# Altium schematic sheet conn_board_adc.SchDoc
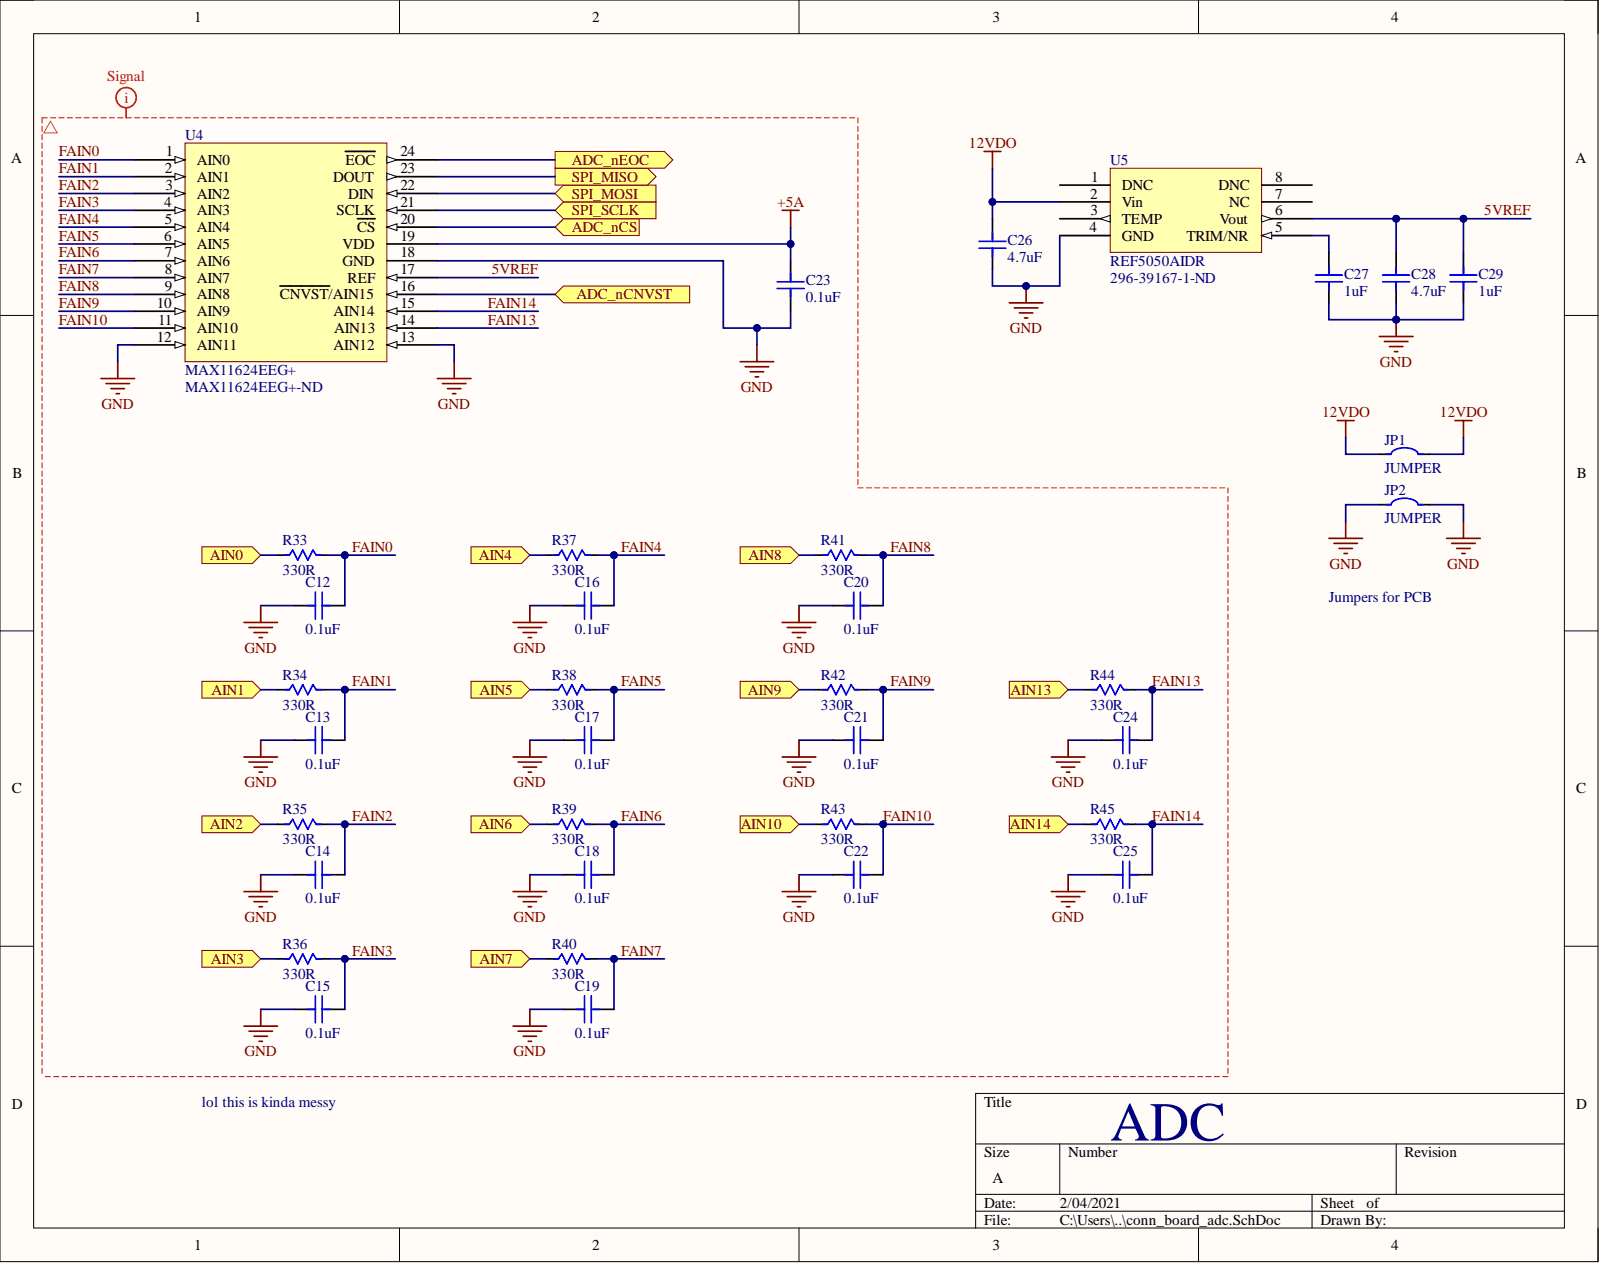
[diagram p0: conn_board_adc.SchDoc]

## Parts
C12  0.1uF  [CAP_0805]
C13  0.1uF  [CAP_0805]
C14  0.1uF  [CAP_0805]
C15  0.1uF  [CAP_0805]
C16  0.1uF  [CAP_0805]
C17  0.1uF  [CAP_0805]
C18  0.1uF  [CAP_0805]
C19  0.1uF  [CAP_0805]
C20  0.1uF  [CAP_0805]
C21  0.1uF  [CAP_0805]
C22  0.1uF  [CAP_0805]
C23  0.1uF  [CAP_0805]
C24  0.1uF  [CAP_0805]
C25  0.1uF  [CAP_0805]
C26  4.7uF  [CAP_0805]
C27  1uF  [CAP_0805]
C28  4.7uF  [CAP_0805]
C29  1uF  [CAP_0805]
JP1  JUMPER  [JMP_20MM]  (jumper)
JP2  JUMPER  [JMP_20MM]  (jumper)
R33  330R  [RES_0805_PANASONIC]
R34  330R  [RES_0805_PANASONIC]
R35  330R  [RES_0805_PANASONIC]
R36  330R  [RES_0805_PANASONIC]
R37  330R  [RES_0805_PANASONIC]
R38  330R  [RES_0805_PANASONIC]
R39  330R  [RES_0805_PANASONIC]
R40  330R  [RES_0805_PANASONIC]
R41  330R  [RES_0805_PANASONIC]
R42  330R  [RES_0805_PANASONIC]
R43  330R  [RES_0805_PANASONIC]
R44  330R  [RES_0805_PANASONIC]
R45  330R  [RES_0805_PANASONIC]
U4  MAX11624EEG+  Supplier Part Number 1: MAX11624EEG+-ND  [QSOP24]
U5  REF5050AIDR  Supplier Part Number 1: 296-39167-1-ND  [SOIC_8]

## Ports
ADC_nCNVST, ADC_nCS, ADC_nEOC, AIN0, AIN1, AIN2, AIN3, AIN4, AIN5, AIN6, AIN7, AIN8, AIN9, AIN10, AIN13, AIN14, SPI_MISO, SPI_MOSI, SPI_SCLK

## Nets
12VDO: C26.2 JP1.1 JP1.2 U5.2(Vin)
GND: C12.1 C13.1 C14.1 C15.1 C16.1 C17.1 C18.1 C19.1 C20.1 C21.1 C22.1 C23.2 C24.1 C25.1 C26.1 C27.1 C28.1 C29.1 JP2.1 JP2.2 U4.12(AIN11) U4.13(AIN12) U4.18(GND) U5.4(GND)
5VREF: C23.1 C28.2 C29.2 U4.17(REF) U4.19(VDD) U5.6(Vout)
ADC_nCNVST: U4.16(CNVST/AIN15) ; port ADC_nCNVST
ADC_nCS: U4.20(CS) ; port ADC_nCS
ADC_nEOC: U4.24(EOC) ; port ADC_nEOC
AIN0: R33.1 ; port AIN0
AIN1: R34.1 ; port AIN1
AIN2: R35.1 ; port AIN2
AIN3: R36.1 ; port AIN3
AIN4: R37.1 ; port AIN4
AIN5: R38.1 ; port AIN5
AIN6: R39.1 ; port AIN6
AIN7: R40.1 ; port AIN7
AIN8: R41.1 ; port AIN8
AIN9: R42.1 ; port AIN9
AIN10: R43.1 ; port AIN10
AIN13: R44.1 ; port AIN13
AIN14: R45.1 ; port AIN14
FAIN0: C12.2 R33.2 U4.1(AIN0)
FAIN1: C13.2 R34.2 U4.2(AIN1)
FAIN2: C14.2 R35.2 U4.3(AIN2)
FAIN3: C15.2 R36.2 U4.4(AIN3)
FAIN4: C16.2 R37.2 U4.5(AIN4)
FAIN5: C17.2 R38.2 U4.6(AIN5)
FAIN6: C18.2 R39.2 U4.7(AIN6)
FAIN7: C19.2 R40.2 U4.8(AIN7)
FAIN8: C20.2 R41.2 U4.9(AIN8)
FAIN9: C21.2 R42.2 U4.10(AIN9)
FAIN10: C22.2 R43.2 U4.11(AIN10)
FAIN13: C24.2 R44.2 U4.14(AIN13)
FAIN14: C25.2 R45.2 U4.15(AIN14)
SPI_MISO: U4.23(DOUT) ; port SPI_MISO
SPI_MOSI: U4.22(DIN) ; port SPI_MOSI
SPI_SCLK: U4.21(SCLK) ; port SPI_SCLK
NetC27_2: C27.2 U5.5(TRIM/NR)

Not connected: U5 1(DNC) 3(TEMP) 7(NC) 8(DNC)

## Library data of the parts
C12-C25 = C0805C104M3RACTU: CAP CER 0.1UF 25V X7R 0805; Manufacturer: KEMET; Capacitance: 100nF; Dielectric Material: Ceramic; Dissipation Factor: 5%; Tolerance: 20%; Case Code (Imperial): 0805; Case Code (Metric): 2012; Case/Package: 0805; Category: Capacitor; Component Kind: Standard; ComponentLink1Description: http://www.mouser.com/ds/2/212/KEM_C1002_X7R_SMD-1102033.pdf?src-supplier=Mouser; ComponentLink1URL: http://www.mouser.com/ds/2/212/KEM_C1002_X7R_SMD-1102033.pdf?src-supplier=Mouser; ComponentLink2Description: http://uk.rs-online.com/webdocs/13da/0900766b813da9ff.pdf?src-supplier=RSComponents; ComponentLink2URL: http://uk.rs-online.com/webdocs/13da/0900766b813da9ff.pdf?src-supplier=RSComponents; ComponentLink3Description: http://components.arrow.com/part/dataview/0323_00178100?src-supplier=Arrow+Europe; ComponentLink3URL: http://components.arrow.com/part/dataview/0323_00178100?src-supplier=Arrow+Europe; ComponentLink4Description: https://www.alliedelec.com/m/d/6f3b5eb83d09db50ed28bdc730567b33.pdf?src-supplier=Allied+Electronics; ComponentLink4URL: https://www.alliedelec.com/m/d/6f3b5eb83d09db50ed28bdc730567b33.pdf?src-supplier=Allied+Electronics; ComponentLink5Description: http://www.farnell.com/datasheets/2746330.pdf?src-supplier=Farnell; ComponentLink5URL: http://www.farnell.com/datasheets/2746330.pdf?src-supplier=Farnell; ComponentLink6Description: http://www.jameco.com/Jameco/Products/ProdDS/1566487.pdf?src-supplier=Jameco; ComponentLink6URL: http://www.jameco.com/Jameco/Products/ProdDS/1566487.pdf?src-supplier=Jameco; ComponentLink7Description: http://components.arrow.com/part/dataview/2091097S3491315N7429?src-supplier=Arrow+Electronics; ComponentLink7URL: http://components.arrow.com/part/dataview/2091097S3491315N7429?src-supplier=Arrow+Electronics; ComponentLink8Description: https://www.alliedelec.com/m/d/e7aa2b5497e2375e4ffc0e8be19e16e2.pdf?src-supplier=Allied+Electronics; ComponentLink8URL: https://www.alliedelec.com/m/d/e7aa2b5497e2375e4ffc0e8be19e16e2.pdf?src-supplier=Allied+Electronics; ComponentLink9Description: http://uk.rs-online.com/webdocs/13da/0900766b813daa05.pdf?src-supplier=RSComponents; ComponentLink9URL: http://uk.rs-online.com/webdocs/13da/0900766b813daa05.pdf?src-supplier=RSComponents; ComponentLink10Description: http://www.farnell.com/datasheets/2746330.pdf?src-supplier=Element14; ComponentLink10URL: http://www.farnell.com/datasheets/2746330.pdf?src-supplier=Element14; ComponentLink11Description: https://api.kemet.com/component-edge/download/datasheet/C0805C104M3RACTU.pdf?src-supplier=Digi-Key; ComponentLink11URL: https://api.kemet.com/component-edge/download/datasheet/C0805C104M3RACTU.pdf?src-supplier=Digi-Key; ComponentLink12Description: http://www.farnell.com/datasheets/1828800.pdf?src-supplier=Newark; ComponentLink12URL: http://www.farnell.com/datasheets/1828800.pdf?src-supplier=Newark; ComponentLink13Description: http://www.farnell.com/datasheets/2746330.pdf?src-supplier=Newark; ComponentLink13URL: http://www.farnell.com/datasheets/2746330.pdf?src-supplier=Newark; ComponentLink14Description: http://capacitoredge.kemet.com/capedge2/DataSheet?pn=C0805C104M3RACTU&src-supplier=Avnet; ComponentLink14URL: http://capacitoredge.kemet.com/capedge2/DataSheet?pn=C0805C104M3RACTU&src-supplier=Avnet; Depth: 1.25mm; Dielectric: X7R; Height: 0.9mm; Lead Pitch: 0.75mm; Length: 2mm; Library Name: Schlib1.SchLib; Manufacturer Part Number: C0805C104M3RACTU; Max Operating Temperature: 125°C; Min Operating Temperature: -55°C; Mount: Surface Mount; Package Quantity: 1; Packaging: Tape and Reel; Pricing 1: 1=0.10000, 10=0.06800, 50=0.03700, 100=0.03020, 500=0.02160, 1000=0.01697 (USD); Radiation Hardening: No; REACH SVHC: No SVHC; RoHS: Yes; Stock 1: 305175; Supplier 1: Digi-Key; Supplier Part Number 1: 399-8000-1-ND; Termination: SMD/SMT; Thickness: 0.035inch; Voltage: 25V; Voltage Rating: 25V; Voltage Rating (DC): 25V; Width: 0.049inch
C26 C28 = CL21A475KAQNNNE: SAMSUNG ELECTRO-MECHANICS - CL21A475KAQNNNE - SMD Multilayer Ceramic Capacitor, 4.7 µF, 25 V, 0805 [2012 Metric], ± 10%, X5R, CL Series; Voltage Rating: 25V; Capacitance: 4.7uF; Case Code (Imperial): 0805; Case Code (Metric): 2012; Case/Package: 0805; Category: Ceramic Capacitors; Component Kind: Standard; ComponentLink1Description: https://datasheet.ciiva.com/25798/cl21a475kaqnnne-25798882.pdf?src-supplier=Digi-Key; ComponentLink1URL: https://datasheet.ciiva.com/25798/cl21a475kaqnnne-25798882.pdf?src-supplier=Digi-Key; Depth: 1.25mm; Dielectric: X5R; Height: 1.25mm; Lead Free: Lead Free; Length: 2mm; Library Name: Schlib1.SchLib; Manufacturer: Samsung; Manufacturer Part Number: CL21A475KAQNNNE; Max Operating Temperature: 85°C; Min Operating Temperature: -55°C; Mount: Surface Mount; Package Quantity: 1; Packaging: Tape and Reel; Pricing 1: 1=0.11000, 10=0.08100, 50=0.04600, 100=0.03820, 500=0.02678, 1000=0.02216 (USD); Radiation Hardening: No; RoHS: Yes; Stock 1: 671990; Supplier 1: Digi-Key; Supplier Part Number 1: 1276-1244-1-ND; Thickness: 0.055inch; Tolerance: 10%; Voltage Rating (DC): 25V; Width: 0.049inch
C27 C29 = C0805C105Z4VACTU: Cap Ceramic 1uF 16V Y5V -20% to 80% Pad SMD 0805 85C T/R; Manufacturer: KEMET; Dissipation Factor: 7%; Capacitance: 1uF; Case Code (Imperial): 0805; Case Code (Metric): 2012; Case/Package: 0805; Category: Capacitor; Component Kind: Standard; ComponentLink1Description: https://datasheet.ciiva.com/6074/1698780-6074369.pdf?src-supplier=Farnell; ComponentLink1URL: https://datasheet.ciiva.com/6074/1698780-6074369.pdf?src-supplier=Farnell; ComponentLink2Description: https://datasheet.ciiva.com/16623/datasheetpn-c0805c105z4vac7800-16623623.pdf?src-supplier=Avnet+Europe; ComponentLink2URL: https://datasheet.ciiva.com/16623/datasheetpn-c0805c105z4vac7800-16623623.pdf?src-supplier=Avnet+Europe; ComponentLink3Description: https://datasheet.ciiva.com/14604/70095383-14604106.pdf?src-supplier=Allied+Electronics; ComponentLink3URL: https://datasheet.ciiva.com/14604/70095383-14604106.pdf?src-supplier=Allied+Electronics; ComponentLink4Description: https://datasheet.ciiva.com/7600/1698780-7600029.pdf?src-supplier=Newark; ComponentLink4URL: https://datasheet.ciiva.com/7600/1698780-7600029.pdf?src-supplier=Newark; ComponentLink5Description: https://datasheet.ciiva.com/14589/70095383-14589407.pdf?src-supplier=Allied+Electronics; ComponentLink5URL: https://datasheet.ciiva.com/14589/70095383-14589407.pdf?src-supplier=Allied+Electronics; ComponentLink6Description: http://datasheet.octopart.com/C0805C105Z4VACTU-Kemet-datasheet-13692877.pdf?src-supplier=Element14; ComponentLink6URL: http://datasheet.octopart.com/C0805C105Z4VACTU-Kemet-datasheet-13692877.pdf?src-supplier=Element14; ComponentLink7Description: http://datasheet.octopart.com/C0805C105Z4VACTU-Kemet-datasheet-503297.pdf?src-supplier=Newark; ComponentLink7URL: http://datasheet.octopart.com/C0805C105Z4VACTU-Kemet-datasheet-503297.pdf?src-supplier=Newark; ComponentLink8Description: http://datasheet.octopart.com/C0805C105Z4VACTU-KEMET-datasheet-101576167.pdf?src-supplier=Farnell; ComponentLink8URL: http://datasheet.octopart.com/C0805C105Z4VACTU-KEMET-datasheet-101576167.pdf?src-supplier=Farnell; ComponentLink9Description: http://datasheet.octopart.com/C0805C105Z4VACTU-KEMET-datasheet-83957834.pdf?src-supplier=TME; ComponentLink9URL: http://datasheet.octopart.com/C0805C105Z4VACTU-KEMET-datasheet-83957834.pdf?src-supplier=TME; ComponentLink10Description: http://datasheet.octopart.com/C0805C105Z4VACTU-Kemet-datasheet-5313890.pdf?src-supplier=Verical; ComponentLink10URL: http://datasheet.octopart.com/C0805C105Z4VACTU-Kemet-datasheet-5313890.pdf?src-supplier=Verical; ComponentLink11Description: http://datasheet.octopart.com/C0805C105Z4VAC-TU-Kemet-datasheet-8204015.pdf?src-supplier=Jameco; ComponentLink11URL: http://datasheet.octopart.com/C0805C105Z4VAC-TU-Kemet-datasheet-8204015.pdf?src-supplier=Jameco; ComponentLink12Description: http://datasheet.octopart.com/C0805C105Z4VACTU-Kemet-datasheet-464.pdf?src-supplier=Allied+Electronics; ComponentLink12URL: http://datasheet.octopart.com/C0805C105Z4VACTU-Kemet-datasheet-464.pdf?src-supplier=Allied+Electronics; ComponentLink13Description: http://datasheet.octopart.com/C0805C105Z4VACTU-Kemet-datasheet-11554470.pdf?src-supplier=Arrow; ComponentLink13URL: http://datasheet.octopart.com/C0805C105Z4VACTU-Kemet-datasheet-11554470.pdf?src-supplier=Arrow; ComponentLink14Description: http://datasheet.octopart.com/C0805C105Z4VACTU-Kemet-datasheet-66128697.pdf?src-supplier=Element14; ComponentLink14URL: http://datasheet.octopart.com/C0805C105Z4VACTU-Kemet-datasheet-66128697.pdf?src-supplier=Element14; ComponentLink15Description: http://datasheet.octopart.com/C0805C105Z4VACTU-Kemet-datasheet-66325528.pdf?src-supplier=Newark; ComponentLink15URL: http://datasheet.octopart.com/C0805C105Z4VACTU-Kemet-datasheet-66325528.pdf?src-supplier=Newark; ComponentLink16Description: http://datasheet.octopart.com/C0805C105Z4VACTU-Kemet-datasheet-67847115.pdf?src-supplier=TME; ComponentLink16URL: http://datasheet.octopart.com/C0805C105Z4VACTU-Kemet-datasheet-67847115.pdf?src-supplier=TME; ComponentLink17Description: http://datasheet.octopart.com/C0805C105Z4VACTU-KEMET-datasheet-84169754.pdf; ComponentLink17URL: http://datasheet.octopart.com/C0805C105Z4VACTU-KEMET-datasheet-84169754.pdf; ComponentLink18Description: http://datasheet.octopart.com/C0805C105Z4VACTU-KEMET-datasheet-41193421.pdf?src-supplier=Master+Electronics; ComponentLink18URL: http://datasheet.octopart.com/C0805C105Z4VACTU-KEMET-datasheet-41193421.pdf?src-supplier=Master+Electronics; Contact Material: Tin; Depth: 1.25mm; Dielectric: Y5V; Dielectric Material: Ceramic; Height: 1.25mm; Lead Free: Lead Free; Lead Pitch: 0.75mm; Length: 2mm; Library Name: Schlib1.SchLib; Manufacturer Part Number: C0805C105Z4VACTU; Max Operating Temperature: 85°C; Min Operating Temperature: -30°C; Mount: Surface Mount; Number of Pins: 2; Package Quantity: 1; Packaging: Tape and Reel; Pricing 1: 1=0.11000, 10=0.08100, 50=0.04420, 100=0.03620, 500=0.02584, 1000=0.02030 (USD); Radiation Hardening: No; REACH SVHC: No SVHC; Stock 1: 83211; Supplier 1: Digi-Key; Supplier Part Number 1: 399-8011-1-ND; Termination: SMD/SMT; Thickness: 0.055inch; Tolerance: 80%; Voltage: 16V; Voltage Rating: 16V; Voltage Rating (DC): 16V; Width: 0.049inch
R33-R45 = ERA-6AED331V: Res Thin Film 0805 330 Ohm 0.5% 0.125W(1/8W) ±25ppm/C Molded SMD Automotive Punched T/R; Manufacturer: Panasonic; Power Rating: 125mW; Temperature Coefficient: 25ppm/°C; Voltage Rating: 200V; Case Code (Imperial): 0805; Case Code (Metric): 2012; Case/Package: 0805; Category: Resistor; Component Kind: Standard; ComponentLink1Description: https://datasheet.ciiva.com/24071/aoa0000ce26-197354-24071480.pdf?src-supplier=Mouser; ComponentLink1URL: https://datasheet.ciiva.com/24071/aoa0000ce26-197354-24071480.pdf?src-supplier=Mouser; ComponentLink2Description: https://datasheet.ciiva.com/9182/aoa0000ce26-9182970.pdf?src-supplier=Avnet; ComponentLink2URL: https://datasheet.ciiva.com/9182/aoa0000ce26-9182970.pdf?src-supplier=Avnet; Contact Plating: Tin; Depth: 1.25mm; Height: 0.5mm; Lead Free: Lead Free; Length: 2mm; Library Name: Schlib1.SchLib; Manufacturer Part Number: ERA-6AED331V; Max Operating Temperature: 155°C; Military Standard: Not; Min Operating Temperature: -55°C; Mount: Surface Mount; Package Quantity: 5000; Packaging: Tape and Reel; Pricing 1: 1=0.10000, 10=0.09400, 25=0.06480, 50=0.05040, 100=0.03600, 250=0.02808, 500=0.02232, 1000=0.01584 (USD); Resistance: 330R; Resistor Type: Thin Film; RoHS: Yes; Stock 1: 11862; Supplier 1: Digi-Key; Supplier Part Number 1: P123837CT-ND; Termination: SMD/SMT; Tolerance: 0.5%; Width: 1.25mm
U4 = MAX11624EEG+: GLOVE, POLYAMIDE, L/FREE, PU, SIZE 6 - More Details; Manufacturer: Maxim; Conversion Rate: 300KS/s; Interface: SPI,Serial; Max Power Dissipation: 762mW; Number of A/D Converters: 1; Number of Analog Inputs: 16; Number of Bits: 10; Operating Supply Voltage: 5.25V; Polarity: Unipolar; Resolution: 10b; Sampling Rate: 300000S/s; Signal to Noise Ratio (SNR): 49dB; Supply Type: Single; Case/Package: QSOP; Category: IC; Component Kind: Standard; ComponentLink1Description: https://datasheet.ciiva.com/16611/max11618-max11625-16611389.pdf?src-supplier=Avnet+Europe; ComponentLink1URL: https://datasheet.ciiva.com/16611/max11618-max11625-16611389.pdf?src-supplier=Avnet+Europe; ComponentLink2Description: https://datasheet.ciiva.com/3039/max11618-max11625-3039027.pdf?src-supplier=Avnet; ComponentLink2URL: https://datasheet.ciiva.com/3039/max11618-max11625-3039027.pdf?src-supplier=Avnet; Library Name: Schlib1.SchLib; Manufacturer Part Number: MAX11624EEG+; Max Operating Temperature: 85°C; Min Operating Temperature: -40°C; Mount: Surface Mount; Number of Channels: 16; Number of Converters: 1; Number of Pins: 24; Package Quantity: 50; Packaging: Rail/Tube; Pricing 1: 1=6.76000, 10=6.11000, 25=5.82520, 100=4.83080, 250=4.82100 (USD); Radiation Hardening: No; RoHS: Yes; Stock 1: 35; Supplier 1: Digi-Key
U5 = REF5050AIDR: TEXAS INSTRUMENTS - REF5050AIDR - VOLTAGE REF, SERIES, 5V, SOIC-8; Max Input Voltage: 18V; Max Output Current: 10mA; Min Input Voltage: 5.2V; Nominal Supply Current: 800uA; Number of Channels: 1; Operating Supply Current: 1.2mA; Output Type: Fixed; Quiescent Current: 1mA; Temperature Coefficient: 3ppm/°C; Tolerance: 0.1%; Case/Package: D; Category: Voltage References; Component Kind: Standard; ComponentLink1Description: https://datasheet.ciiva.com/4065/43001021s8324725n7713-4065643.pdf?src-supplier=Arrow+Electronics; ComponentLink1URL: https://datasheet.ciiva.com/4065/43001021s8324725n7713-4065643.pdf?src-supplier=Arrow+Electronics; ComponentLink2Description: https://datasheet.ciiva.com/3236/ref5050-3236312.pdf?src-supplier=Avnet; ComponentLink2URL: https://datasheet.ciiva.com/3236/ref5050-3236312.pdf?src-supplier=Avnet; ComponentLink3Description: https://datasheet.ciiva.com/2012/ref5020-2012761.pdf?src-supplier=Digi-Key; ComponentLink3URL: https://datasheet.ciiva.com/2012/ref5020-2012761.pdf?src-supplier=Digi-Key; Lead Free: Lead Free; Library Name: Schlib1.SchLib; Manufacturer: Texas Instruments; Manufacturer Part Number: REF5050AIDR; Max Operating Temperature: 125°C; Min Operating Temperature: -40°C; Min Output Voltage: 5V; Number of Pins: 8; Output Current: 10mA; Output Voltage: 5V; Package Quantity: 2500; Packaging: Tape and Reel; Pricing 1: 1=2.92000, 10=2.61800, 25=2.47520, 100=2.03500, 250=1.82600, 500=1.76000, 1000=1.46300 (USD); Radiation Hardening: No; REACH SVHC: No SVHC; Reference Type: Series; Reference Voltage: 5V; RoHS: Yes; Stock 1: 3640; Supplier 1: Digi-Key; Weight: 0.002677oz
JP1 JP2 = JUMPER: Library Name: Schlib1.SchLib
